# Altium schematic sheet PiggySat-power.SchDoc(PiggySat-power)
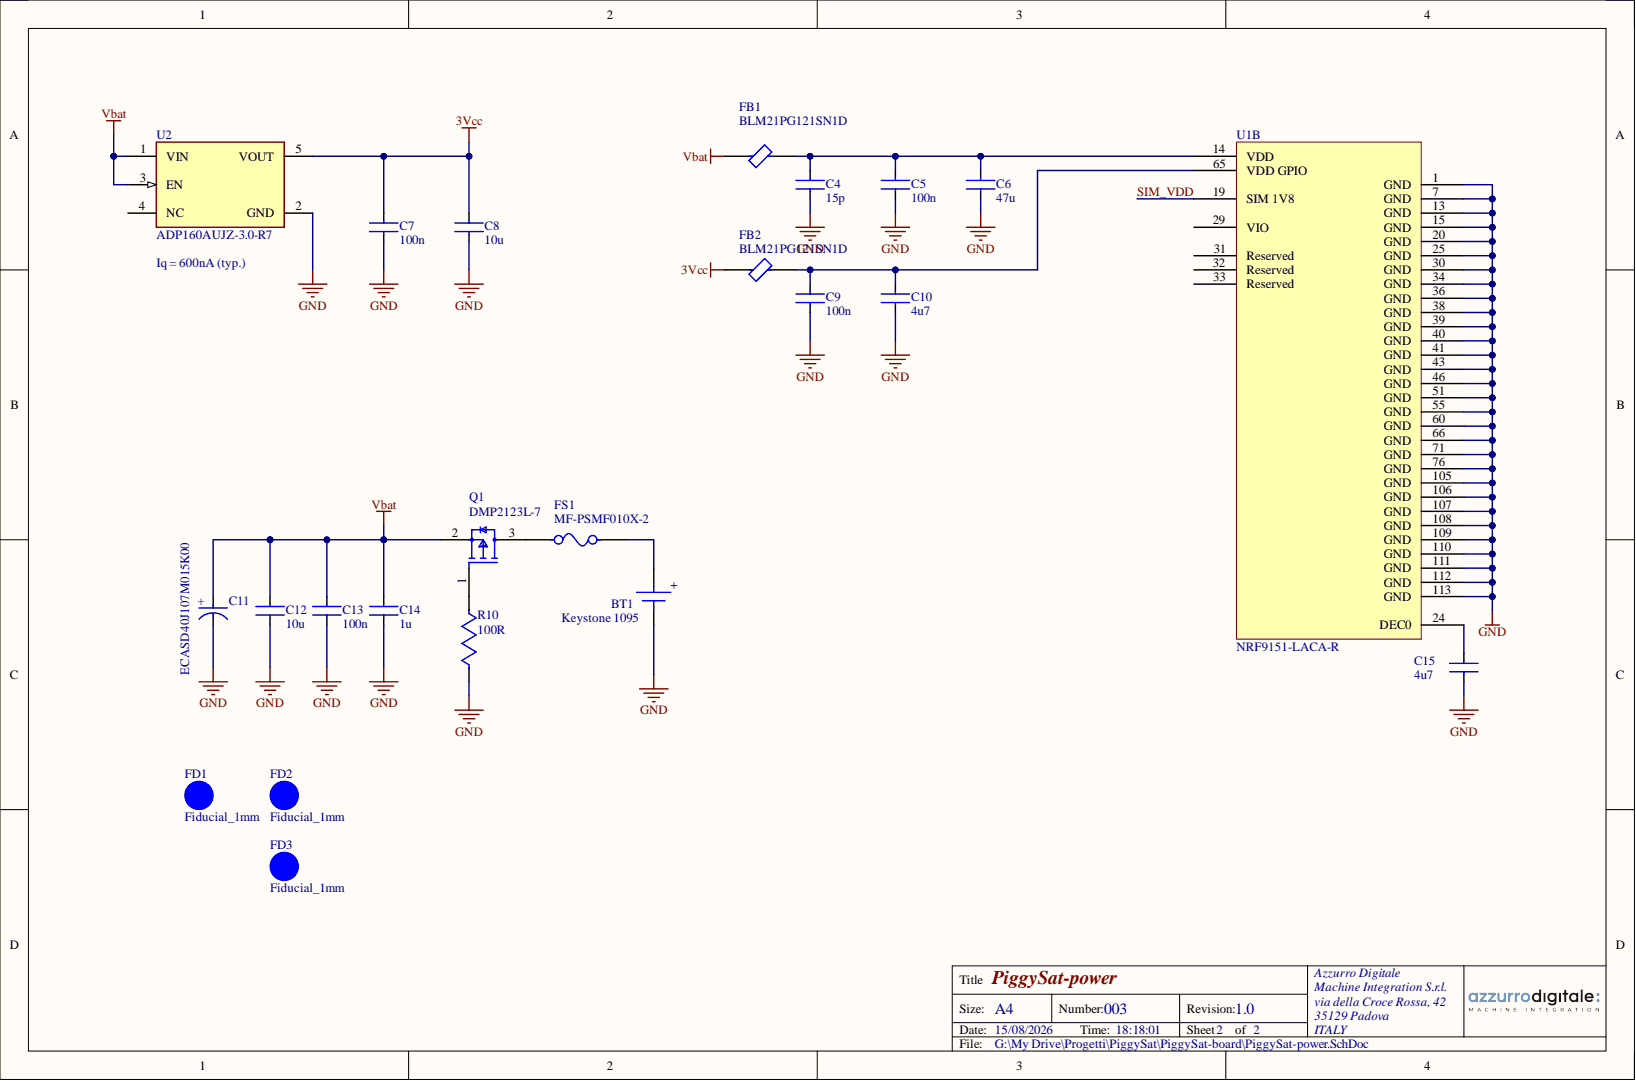
[diagram p0: PiggySat-power.SchDoc]

## Parts
BT1  Keystone 1095  [KEYSTONE 1095]
C4  15p  [CAPC1608X06L]
C5  100n  [CAPC1608X06L]
C6  47u  [CAPC2012X11L]
C7  100n  [CAPC1608X06L]
C8  10u  [CAPC1608X06L]
C9  100n  [CAPC1608X06L]
C10  4u7  [CAPC1608X06L]
C11  ECASD40J107M015K00  [FP-ECAS-D4-MFG]
C12  10u  [CAPC1608X06L]
C13  100n  [CAPC1608X06L]
C14  1u  [CAPC1608X06L]
C15  4u7  [CAPC1608X06L]
FB1  BLM21PG121SN1D  [FP-BLM21-0_2-t0_85-e0_5-d-IPC_C]
FB2  BLM21PG121SN1D  [FP-BLM21-0_2-t0_85-e0_5-d-IPC_C]
FD1  Fiducial_1mm  [Fiducial_1mm-Footprint-1]  (no BOM)
FD2  Fiducial_1mm  [Fiducial_1mm-Footprint-1]  (no BOM)
FD3  Fiducial_1mm  [Fiducial_1mm-Footprint-1]  (no BOM)
FS1  MF-PSMF010X-2  [FP-MF-PSMF-MFG]
Q1  DMP2123L-7  [FP-SOT23-MFG]
R10  100R  [RESC1005X04L]
U1  NRF9151-LACA-R  [FP-nRF9151-LACA-R_MFG]  (part B)
U2  ADP160AUJZ-3.0-R7  [FP-UJ-5-IPC_A]

## Nets
3Vcc: C7.2 C8.2 FB2.2 U2.5(VOUT)
GND: BT1.N C4.1 C5.1 C6.1 C7.1 C8.1 C9.1 C10.1 C11.1 C12.1 C13.1 C14.1 C15.1 R10.2 U1.1(GND) U1.7(GND) U1.13(GND) U1.15(GND) U1.20(GND) U1.25(GND) U1.30(GND) U1.34(GND) U1.36(GND) U1.38(GND) U1.39(GND) U1.40(GND) U1.41(GND) U1.43(GND) U1.46(GND) U1.51(GND) U1.55(GND) U1.60(GND) U1.66(GND) U1.71(GND) U1.76(GND) U1.105(GND) U1.106(GND) U1.107(GND) U1.108(GND) U1.109(GND) U1.110(GND) U1.111(GND) U1.112(GND) U1.113(GND) U2.2(GND)
Vbat: C11.2 C12.2 C13.2 C14.2 FB1.2 Q1.2 U2.1(VIN) U2.3(EN)
SIM_VDD: U1.19(SIM_1V8)
NetBT1_P: BT1.P FS1.2
NetC4_2: C4.2 C5.2 C6.2 FB1.1 U1.14(VDD)
NetC9_2: C9.2 C10.2 FB2.1 U1.65(VDD_GPIO)
NetC15_2: C15.2 U1.24(DEC0)
NetFS1_1: FS1.1 Q1.3
NetQ1_1: Q1.1 R10.1

Not connected: U1 29(VIO) 31(Reserved) 32(Reserved) 33(Reserved); U2 4(NC)

## Library data of the parts
BT1 = Keystone 1095: THM Holder for 18350 Battery P/N 1095; Component Kind: Standard; Library Name: PiggySat.IntLib
C4 = CMP-001-00001-3: Cap 16V 15p X7R 0603 10%; Component Class: Capacitors; Component Kind: Standard; Library Name: AZZURRODIGITALE MACHINE INTEGRATION SRL
C5 C7 C9 C13 = CMP-001-00001-3: Cap 16V 100n X7R 0603 10%; Component Class: Capacitors; Component Kind: Standard; Library Name: AZZURRODIGITALE MACHINE INTEGRATION SRL
C6 = CMP-001-00002-1: Cap 16V 47u X7R 0603 10%; Component Kind: Standard; Library Name: AZZURRODIGITALE MACHINE INTEGRATION SRL
C8 C12 = CMP-001-00001-3: Cap 16V 10u X7R 0603 10%; Component Class: Capacitors; Component Kind: Standard; Library Name: AZZURRODIGITALE MACHINE INTEGRATION SRL
C10 C15 = CMP-001-00001-3: Cap 16V 4.7u X7R 0603 10%; Component Class: Capacitors; Component Kind: Standard; Library Name: AZZURRODIGITALE MACHINE INTEGRATION SRL
C11 = CMP-06031-000020-2: Polymer Aluminum Electrolytic Capacitor 2917 100uF±20% 6.3V 15mΩ; Capacitance: 100uF; ESR (Equivalent Series Resistance): 15mR; Life (Hours): 1000Hour; Polarity: Polar; Resistance: 0.015R; Ripple Current: 2A; Tolerance: 20%; Case Code (Imperial): 2917; Case Code (Metric): 7343; Case/Package: 2917; Component Kind: Standard; Construction: Flat; Depth: 4.3mm; Height: 1.9mm; Height - Seated (Max): 0.075inch; Lead Free: Lead Free; Length: 7.3mm; Library Name: Altium Content Vault; Max Operating Temperature: 105°C; Min Operating Temperature: -40°C; Mount: Surface Mount; Package Quantity: 1; Packaging: Tape and Reel; Radiation Hardening: No; RoHS Compliant: Yes; Series: ECAS; Termination: SMD/SMT; Voltage Rating: 6.3V; Voltage Rating (DC): 6.3V; Weight: 0.023634oz; Width: 0.169inch
C14 = CMP-001-00001-3: Cap 16V 1u X7R 0603 10%; Component Class: Capacitors; Component Kind: Standard; Library Name: AZZURRODIGITALE MACHINE INTEGRATION SRL
FB1 FB2 = CMP-0686-00612-3: Chip Ferrite Bead, 0805, 120Ω @ 100MHz, 0.03Ω, 25%, 3A; DC Resistance (DCR): 30mR; Impedance: 120R; Material: Steel; Number of Circuits: 1; Case Code (Imperial): 0805; Case Code (Metric): 2012; Case/Package: 0805; Component Kind: Standard; Current Rating: 3A; DC Resistance-Max: 30mR; Depth: 1.25mm; Height: 0.85mm; Lead Free: Lead Free; Length: 2mm; Library Name: Altium Content Vault; Max Current Rating: 3A; Max DC Current: 3A; Max Operating Temperature: 125°C; Min Operating Temperature: -55°C; Number of Lines: 1; Number of Pins: 2; Package Quantity: 1; Packaging: Tape and Reel; REACH SVHC: No SVHC; RoHS Compliant: Yes; Series: BLM21P; Termination: SMD/SMT; Test Frequency: 100MHz; Tolerance: 25%; Width: 1.25mm
FD1-FD3 = CMP-00017-7: 1mm fiducial marker; Library Name: AZZURRODIGITALE MACHINE INTEGRATION SRL
FS1 = CMP-07400-000017-1: PTC RESET FUSE 15V 100MA 0805; Manufacturer: Bourns Inc.; Current Rating: 40A; Hold Current: 0.1A; Resistance: 1-7.5Ω; Trip Current: 0.3A; Trip Time: 1.5s; Value: 1-7.5Ω; Ambient Temperature Range High: +85°C; Ambient Temperature Range Low: -40°C; Automotive: No; Category: Fuse; Component Kind: Standard; ComponentLink2Description: Datasheet; ComponentLink2URL: https://www.bourns.com/docs/Product-Datasheets/mfpsmf.pdf; Device Class L1: Circuit Protection; Device Class L2: PTC Resettable Fuses; Device Class L3: unset; Digikey Description: PTC RESET FUSE 15V 100MA 0805; Height: 0.85mm; Ipc Land Pattern Name: CAPC215135X67; Lead Free: Yes; Library Name: Altium Content Vault; Manufacturer Part Number: MF-PSMF010X-2; Mouser Description: Resettable Fuses - PPTC 0.10A 15V 1ohm; Octopart: https://octopart.com/mf-psmf010x-2-bourns-7483441; Package: MF-PSMF; RoHS: TRUE; Temperature: -40°C to +85°C; Temperature Range High: +85°C; Temperature Range Low: -40°C; Voltage Rating: 15V
Q1 = CMP-00461-12441635-1: DMP2123L-7 P-Channel 20 V 72 mO Surface Mount Enhancement Mode Mosfet - SOT-23; Continuous Drain Current (ID): -3A; Drain to Source Breakdown Voltage: -20V; Drain to Source Resistance: 51mΩ; Element Configuration: Single; Fall Time: 41ns; Gate to Source Voltage (Vgs): 12V; Input Capacitance: 443pF; Max Junction Temperature (Tj): 150°C; Max Power Dissipation: 1.4W; Number of Channels: 1; Rds On Max: 72mΩ; Rise Time: 20ns; Turn-Off Delay Time: 38ns; Turn-On Delay Time: 12ns; Case/Package: SOT-23-3; Component Kind: Standard; Drain to Source Voltage (Vdss): -20V; Height: 1.1mm; Lead Free: Lead Free; Length: 2.9mm; Library Name: Altium Content Vault; Max Operating Temperature: 150°C; Min Operating Temperature: -55°C; Mount: Surface Mount; NexarPartId: 12441635; Number of Elements: 1; Number of Pins: 3; Power Dissipation: 1.4W; Radiation Hardening: No; REACH SVHC: No SVHC; Resistance: 72mΩ; RoHS: Compliant; Schedule B: 8541290080, 8541290080; Weight: 7.994566mg; Width: 1.3mm
R10 = CMP-009-00001-2: Res 100R 0402; Component Kind: Standard; Library Name: AZZURRODIGITALE MACHINE INTEGRATION SRL
U1 = CMP-00045-2: Lower Power SIP for cellular IoT and DECT NR+, Compatible with nRF Cloud Services; Bandwidth: 1.5GHz; Clock Frequency: 2.2GHz; Data Rate: 3.4Mbps; Interface: 2-Wire, I2C, I2S, SPI, UART, USB; Max Supply Voltage: 5.5V; Min Supply Voltage: 1.7V; Number of ADC Channels: 8; Number of GPIO: 32; Ambient Temperature Range High: 85°C; Component Kind: Standard; Height: 1.3mm; Max Operating Temperature: 85°C; Min Operating Temperature: -40°C; RoHS: Compliant; Library Name: AZZURRODIGITALE MACHINE INTEGRATION SRL
U2 = CMP-09138-000096-1: IC REG LINEAR 3V 150MA TSOT5; Accuracy: 2%; Dropout Voltage: 120mV; Max Input Voltage: 5.5V; Max Output Voltage: 4.2V; Max Supply Current: 42uA; Min Current Limit: 220mA; Min Input Voltage: 2.2V; Min Output Voltage: 1.2V; Number of Outputs: 1; Output Type: Fixed; Polarity: Positive; Power Supply Rejection Ratio (PSRR): 60dB; Quiescent Current: 0mA; Case/Package: TSOT; Component Kind: Standard; Contact Plating: Tin; Height: 0.9mm; Lead Free: Contains Lead; Length: 2.9mm; Library Name: Altium Content Vault; Max Operating Temperature: 125°C; Max Output Current: 150mA; Min Operating Temperature: -40°C; Mount: Surface Mount; Nominal Supply Current: 42uA; Number of Pins: 5; Number of Regulators: 1; Operating Supply Current: 42uA; Output Current: 150mA; Output Voltage: 3V; Output Voltage Accuracy: 2%; Packaging: Tape and Reel; RoHS Compliant: Yes; Series: -; Width: 1.6mm
